# Altium schematic sheet Sensors.SchDoc(Sensors)
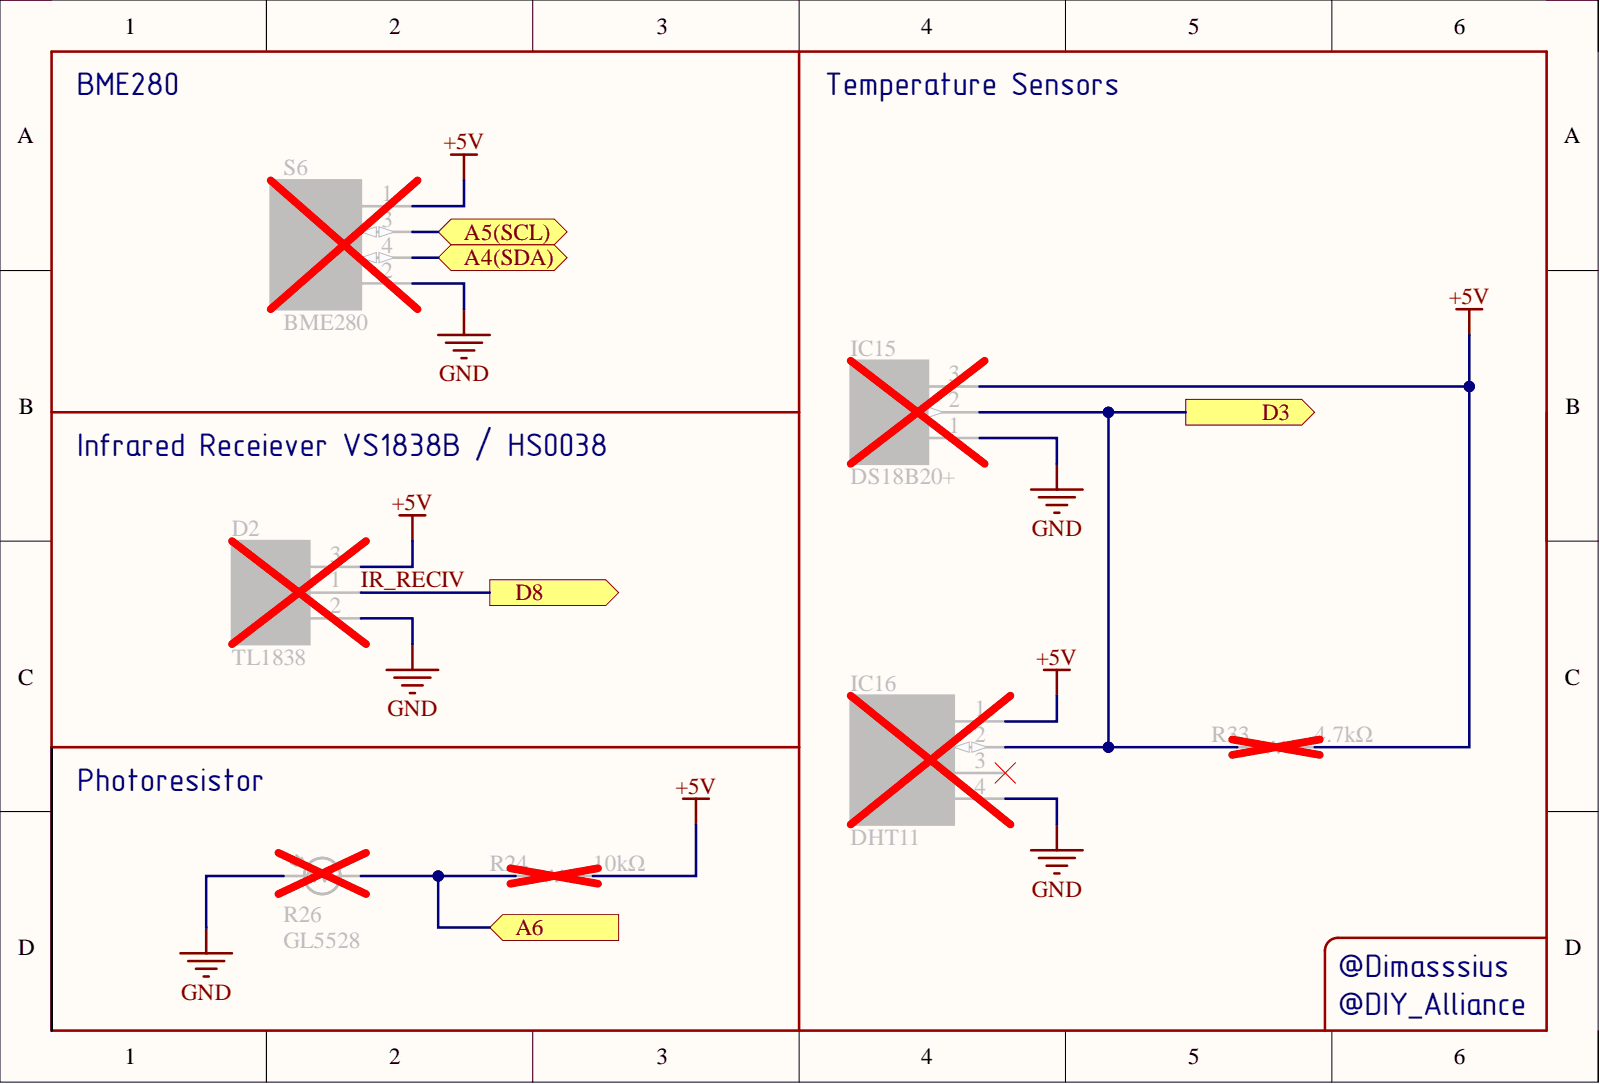
[diagram p0: Sensors.SchDoc]

## Parts
D2  TL1838  [TSOP4856]
IC15  DS18B20+  [TO-92]
IC16  DHT11  [DHT11 - SMD]
R24  10kΩ  [RES_SMD_R0603_(M1608)_R0402A_(M1005A)]
R26  GL5528  [Photoresistor]
R33  4.7kΩ  [RES_SMD_R0603_(M1608)_R0402A_(M1005A)]
S6  BME280  [BME280_DEVBOARD]

## Ports
A4(SDA), A5(SCL), A6, D3, D8

## Nets
+5V: D2.3 IC15.3 IC16.1(VDD) R24.2 R33.2 S6.1(VIN)
GND: D2.2 IC15.1 IC16.4(GND) R26.1 S6.2(GND)
A4(SDA): S6.4(SDA) ; port A4(SDA)
A5(SCL): S6.3(SCL) ; port A5(SCL)
A6: R24.1 R26.2 ; port A6
D3: IC15.2 IC16.2(DATA) R33.1 ; port D3
IR_RECIV: D2.1 ; port D8

Not connected: IC16 3(NC)

## Library data of the parts
D2 = TL1838: Remote Receiver Sensor, 38.0kHz, 45m, Through Hole; Component Kind: Standard; Datasheet Link: http://www.mouser.com/datasheet/2/427/introductionpartnumbers-537189.pdf; Library Name: SamacSys.SchLib; Value: TL1838
IC15 = DS18B20+: Temperature Sensor, THD, TO-92, 3V~5.5V, -55℃~+125℃, ±0.5, Single Bus, RoHS; Component Kind: Standard; Datasheet Link: https://ms.componentsearchengine.com/Datasheets/2/DS18B20+.pdf; Height: 4.95mm; Library Name: SamacSys.SchLib; Value: DS18B20+
IC16 = DHT11: Temperature and Humidity Sensor, SIP-4, ROHS; Component Kind: Standard; Library Name: SamacSys.SchLib; Value: DHT11
R24 = RES_SMD_10KΩ: Resistor, Thick Film Resistor, SMD, 10kΩ, ±5%, Chip Resistor - Surface Mount, ROHS; Component Kind: Standard; Library Name: Resistors.SchLib; Value: 10kΩ
R26 = GL5528: Photoresistor, Through Hole, P=3mm, 10kΩ~20kΩ, 540nm, 1MΩ, Photoresistors, ROHS; Component Kind: Standard; Datasheet Link: https://datasheet.datasheetarchive.com/originals/distributors/Datasheets-DGA19/1465.pdf; Library Name: SamacSys.SchLib; Value: GL5528
R33 = RES_SMD_4.7KΩ: Resistor, Thick Film Resistor, SMD, 4.7kΩ, ±5%, Chip Resistor - Surface Mount, ROHS; Component Kind: Standard; Library Name: Resistors.SchLib; Value: 4.7kΩ
S6 = BME280_DEVBOARD: Development Board, LGA-8(2.5x2.5), Temperature and Humidity Sensor, ROHS; Component Kind: Standard; Library Name: SamacSys.SchLib; Value: BME280
